# Altium schematic sheet E53_SC1.SchDoc
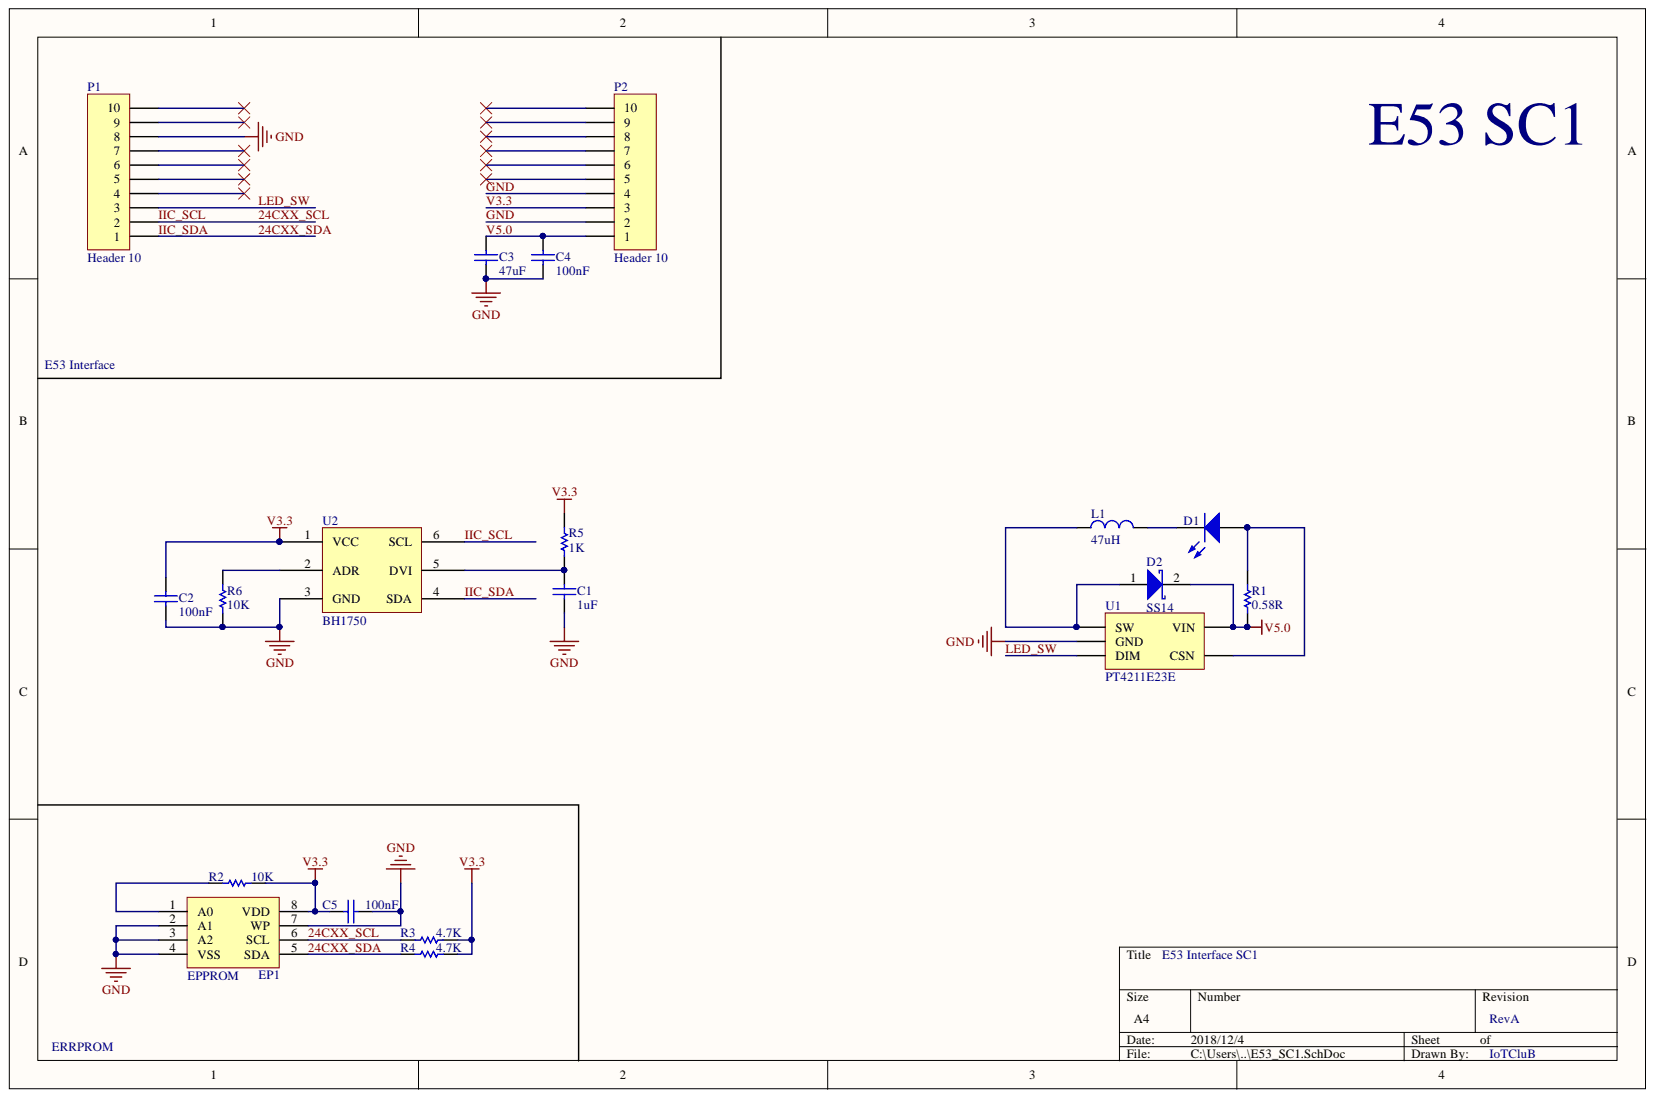
[diagram p0: E53_SC1.SchDoc]

## Parts
C1  1uF  [C0603]
C2  100nF  [C0603]
C3  47uF  [LC-0805_C]
C4  100nF  [C0603]
C5  100nF  [C0603]
D1  LED  [LED 1W/3W-W]  (value not drawn)
D2  SS14  [DIODE_1]
EP1  EPPROM  [SO-8]
L1  47uH  [CD7x]
P1  Header 10  [HDR1X10]
P2  Header 10  [HDR1X10]
R1  0.58R  [R1206]
R2  10K  [R0603]
R3  4.7K  [R0603]
R4  4.7K  [R0603]
R5  1K  [R0603]
R6  10K  [R0603]
U1  PT4211E23E  [SOT23-5]
U2  BH1750  [BH1750]

## Nets
24CXX_SCL: EP1.6(SCL) P1.2 R3.2 U2.6(SCL)
24CXX_SDA: EP1.5(SDA) P1.1 R4.2 U2.4(SDA)
GND: C1.1 C2.1 C3.1 C4.1 C5.1 EP1.2(A1) EP1.3(A2) EP1.4(VSS) EP1.7(WP) P1.8 P2.2 P2.4 R6.1 U1.2(GND) U2.3(GND)
LED_SW: P1.3 U1.23(DIM)
V3.3: C2.2 C5.2 EP1.8(VDD) P2.3 R2.2 R3.1 R4.1 R5.2 U2.1(VCC)
V5.0: C3.2 C4.2 D2.2 P2.1 R1.1 U1.5(VIN)
NetC1_2: C1.2 R5.1 U2.5(DVI)
NetD1_1: D1.1 R1.2 U1.4(CSN)
NetD1_2: D1.2 L1.2
NetD2_1: D2.1 L1.1 U1.1(SW)
NetEP1_1: EP1.1(A0) R2.1
NetR6_2: R6.2 U2.2(ADR)

Not connected: P1 4 5 6 7 9 10; P2 5 6 7 8 9 10

## Library data of the parts
C1-C5 = CAP: Capacitor; Component Kind: Standard; Library Name: Devices.IntLib
D1 = LED1: Typical RED GaAs LED; Component Kind: Standard; LatestRevisionDate: 08-Jul-2005; LatestRevisionNote: Mech Layer 1 removed.; Library Name: Devices.IntLib; PackageDescription: LED; 2 Leads; PackageReference: LED-1; Published: 8-Jun-2000; Simulation: LED1; Publisher: Altium Limited
D2 = D Schottky: Schottky Diode; Code_JEDEC: DO-214-AA; Component Kind: Standard; LatestRevisionDate: 17-Jul-2002; LatestRevisionNote: Re-released for DXP Platform.; Library Name: Devices.IntLib; PackageDescription: DO-214-AA/SMB; 2 C-Bend Leads; Body 5.3 x 3.6 mm, inc. leads (LxW); PackageReference: SMB; Published: 8-Jun-2000; Signal Integrity: D Schottky; Simulation: SKYDIODE; Publisher: Altium Limited
EP1 = EPPROM: ROM: 24C02; Component Kind: Standard; Library Name: IC.IntLib
L1 = Inductor: Code_IEC: 1005; Code_JEITA: 0402; Component Kind: Standard; Description: Inductor; LatestRevisionDate: 29-May-2009; LatestRevisionNote: IPC-7351 Footprint Added.; Library Name: Devices.IntLib; PackageDescription: Chip Inductor; PackageReference: 0402-A; Published: 8-Jun-2000; Signal Integrity: Inductor; Simulation: INDUCTOR; Publisher: Altium Limited
P1 P2 = Header 10: Header, 10-Pin; Component Kind: Standard; LatestRevisionDate: 17-Jul-2002; LatestRevisionNote: Re-released for DXP Platform.; Library Name: Connectors.IntLib; Signal Integrity: Connector; Publisher: Altium Limited
R1-R4 R6 = Res1: Resistor; Value: 1K; Component Kind: Standard; LatestRevisionDate: 17-Jul-2002; LatestRevisionNote: Re-released for DXP Platform.; Library Name: Devices.IntLib; PackageDescription: Axial Device, Thru-Hole; 2 Leads; 0.3 in Pin Spacing; PackageReference: AXIAL-0.3; Published: 8-Jun-2000; Signal Integrity: Res; Simulation: RESISTOR; Publisher: Altium Limited
R5 = Res1: Resistor; Component Kind: Standard; LatestRevisionDate: 17-Jul-2002; LatestRevisionNote: Re-released for DXP Platform.; Library Name: Devices.IntLib; PackageDescription: Axial Device, Thru-Hole; 2 Leads; 0.3 in Pin Spacing; PackageReference: AXIAL-0.3; Published: 8-Jun-2000; Signal Integrity: Res; Simulation: RESISTOR; Value: 1K; Publisher: Altium Limited
U1 = PT4211E23E: Component Kind: Standard; Library Name: Schlib1.SchLib
U2 = BH1750: Component Kind: Standard; Library Name: Schlib1.SchLib
